# Altium schematic sheet Sheet1.SchDoc(Sheet1)
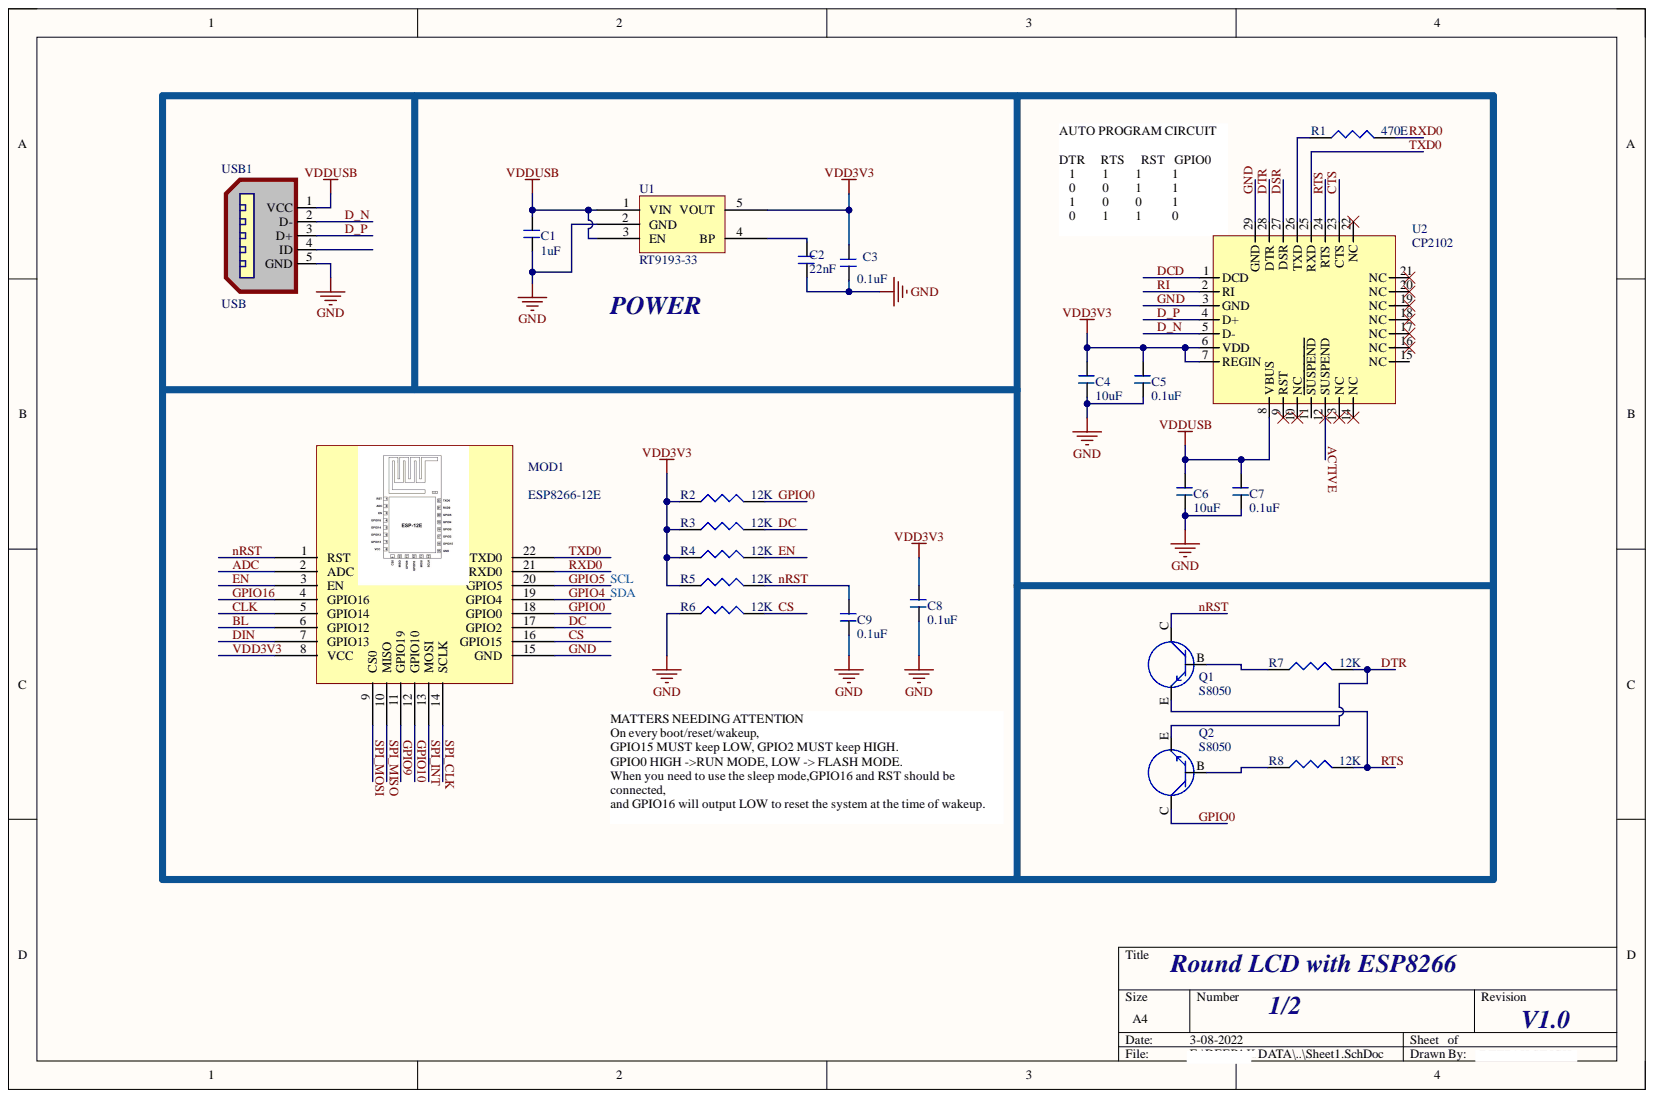
[diagram p0: Sheet1.SchDoc]

## Parts
C1  1uF  [CAP_0603_NONPOLAR]
C2  22nF  [CAP_0603_NONPOLAR]
C3  0.1uF  [CAP_0603_NONPOLAR]
C4  10uF  [CAP_0603_NONPOLAR]
C5  0.1uF  [CAP_0603_NONPOLAR]
C6  10uF  [CAP_0603_NONPOLAR]
C7  0.1uF  [CAP_0603_NONPOLAR]
C8  0.1uF  [CAP_0603_NONPOLAR]
C9  0.1uF  [CAP_0603_NONPOLAR]
MOD1  ESP8266-12E  [ESP8266-12E/ESP-12E]
Q1  S8050  [547_SOT23]
Q2  S8050  [547_SOT23]
R1  470E  [0603]
R2  12K  [0603]
R3  12K  [0603]
R4  12K  [0603]
R5  12K  [0603]
R6  12K  [0603]
R7  12K  [0603]
R8  12K  [0603]
U1  RT9193-33  [SOT-23-5]
U2  CP2102  [QFN28]
USB1  USB  [USB_MICRO]

## Nets
VDDUSB: C1.1 C6.1 C7.1 U1.1(VIN) U1.3(EN) U2.8(VBUS) USB1.1(VCC)
ACTIVE: U2.12(SUSPEND)
ADC: MOD1.2(ADC)
CTS: U2.23(CTS)
D_N: U2.5(D-) USB1.2(D-)
D_P: U2.4(D+) USB1.3(D+)
DCD: U2.1(DCD)
DSR: U2.27(DSR)
DTR: Q2.E R7.2 U2.28(DTR)
EN: MOD1.3(EN) R4.2
GND: C1.2 C2.2 C3.2 C4.2 C5.2 C6.2 C7.2 C8.2 C9.2 MOD1.15(GND) R6.1 U1.2(GND) U2.3(GND) U2.29(GND) USB1.5(GND)
GPIO0: MOD1.18(GPIO0) Q2.C R2.2
GPIO2: MOD1.17(GPIO2) R3.2
GPIO4: MOD1.19(GPIO4)
GPIO5: MOD1.20(GPIO5)
GPIO9: MOD1.11(GPIO19)
GPIO10: MOD1.12(GPIO10)
GPIO12: MOD1.6(GPIO12)
GPIO13: MOD1.7(GPIO13)
GPIO14: MOD1.5(GPIO14)
GPIO15: MOD1.16(GPIO15) R6.2
GPIO16: MOD1.4(GPIO16)
nRST: C9.1 MOD1.1(RST) Q1.C R5.2
RI: U2.2(RI)
RTS: Q1.E R8.2 U2.24(RTS)
RXD0: MOD1.21(RXD0) R1.2
SPI_CLK: MOD1.14(SCLK)
SPI_INT: MOD1.13(MOSI)
SPI_MISO: MOD1.10(MISO)
SPI_MOSI: MOD1.9(CS0)
TXD0: MOD1.22(TXD0) U2.25(RXD)
VDD3V3: C3.1 C4.1 C5.1 C8.1 MOD1.8(VCC) R2.1 R3.1 R4.1 R5.1 U1.5(VOUT) U2.6(VDD) U2.7(REGIN)
NetC2_1: C2.1 U1.4(BP)
NetQ1_B: Q1.B R7.1
NetQ2_B: Q2.B R8.1
NetR1_1: R1.1 U2.26(TXD)

Not connected: U2 9(RST) 10(NC) 11(SUSPEND) 13(NC) 14(NC) 15(NC) 16(NC) 17(NC) 18(NC) 19(NC) 20(NC) 21(NC) 22(NC); USB1 4(ID)

## Library data of the parts
C1-C9 = CAP_NONPOLAR: Component Kind: Standard; Library Name: dxp_pasl_lib.IntLib
MOD1 = ESP8266-12E: WiFi module, 32-bit MCU micro, 80 MHz or 160 MHz, Supports the RTOS and integrated Wi-Fi MAC/BB/RF/PA/LNA, on-board antenna.; Component Kind: Standard; Library Name: dxp_pasl_lib.IntLib
Q1 Q2 = TRANSISTER_NPN: Component Kind: Standard; Library Name: dxp_pasl_lib.IntLib
R1-R8 = RES: Component Kind: Standard; Library Name: dxp_pasl_lib.IntLib
U2 = CP2102_1: QFN, 28-Leads, Body 5.0x5.0mm (max), Pitch 0.50mm, IPC Medium Density; Component Kind: Standard; Library Name: dxp_pasl_lib.IntLib
USB1 = USB_MICRO: Micro USB Connector SMD – TH Support Legs – 5 Pin – Type B; Component Kind: Standard; Library Name: dxp_pasl_lib.IntLib
U1 = RT9193-33: Component Kind: Standard; Library Name: dxp_pasl_lib.IntLib
